# Altium schematic sheet PWR_Battery_Protection.SchDoc
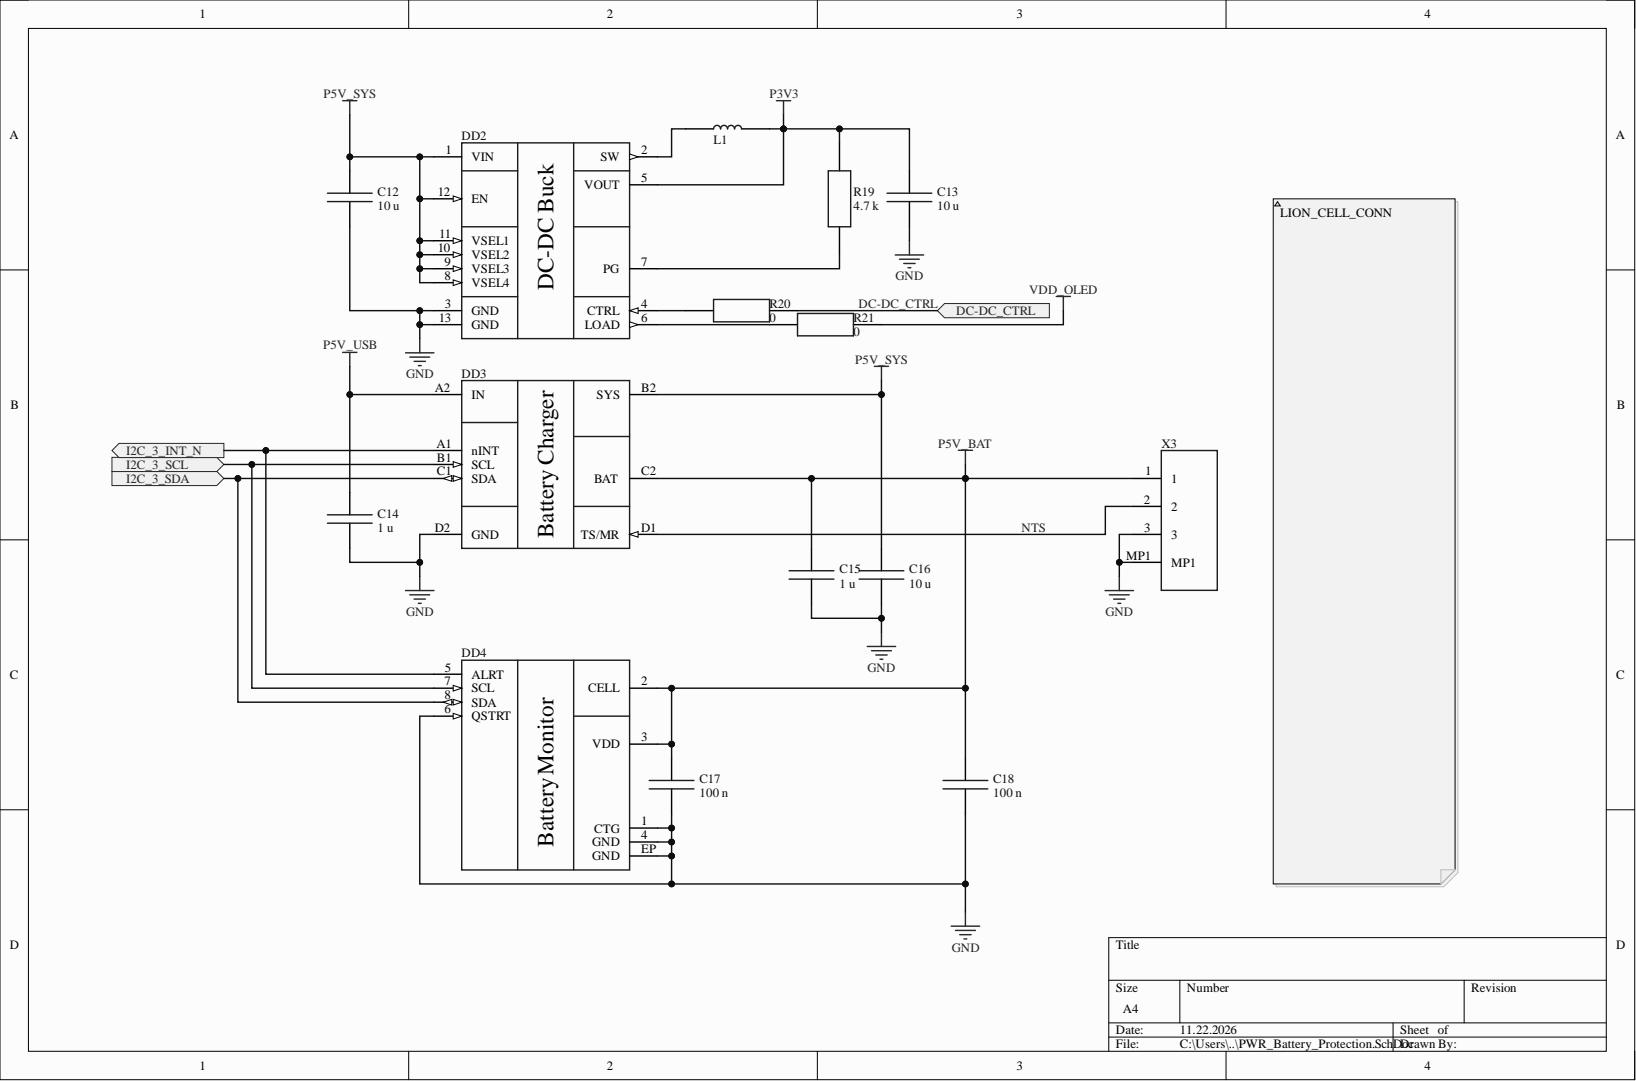
[diagram p0: PWR_Battery_Protection.SchDoc]

## Parts
C12  10 u  [C0603]
C13  10 u  [C0603]
C14  1 u  [C0603]
C15  1 u  [C0603]
C16  10 u  [C0603]
C17  100 n  [C0402]
C18  100 n  [C0402]
DD2  TPS62740DSSR  [WSON-12_3x2_P0.5_EP0.9x2]  (value not drawn)
DD3  BQ25180YBGR  [DSBGA_TI_BQ25180YBGR]  (value not drawn)
DD4  MAX17048G+T10  [TDFN-8_2x2_P0.5_EP0.8x1.38]  (value not drawn)
L1  2.2 uH  [IND3.3x3.3]  (value not drawn)
R19  4.7 k  [R0402]
R20  0  [R0402]
R21  0  [R0402]
X3  S3B-PH-SM4-TB(LF)(SN)  [JST_S3B-PH-SM4-TB_LF_SN]  (value not drawn)

## Ports
DC-DC_CTRL, I2C_3_INT_N, I2C_3_SCL, I2C_3_SDA

## Nets
GND: C12.2 C13.2 C14.2 C15.2 C16.2 C17.2 C18.2 DD2.3(GND) DD2.13(GND) DD3.D2(GND) DD4.1(CTG) DD4.4(GND) DD4.6(QSTRT) DD4.EP(GND) X3.3 X3.MP1
P3V3: C13.1 DD2.5(VOUT) L1.2 R19.2
P5V_BAT: C15.1 C17.1 C18.1 DD3.C2(BAT) DD4.2(CELL) DD4.3(VDD) X3.1
P5V_SYS: C12.1 C16.1 DD2.1(VIN) DD2.8(VSEL4) DD2.9(VSEL3) DD2.10(VSEL2) DD2.11(VSEL1) DD2.12(EN) DD3.B2(SYS)
P5V_USB: C14.1 DD3.A2(IN)
VDD_OLED: R21.2
DC-DC_CTRL: R20.2 ; port DC-DC_CTRL
I2C_3_INT_N: DD3.A1(nINT) DD4.5(ALRT) ; port I2C_3_INT_N
I2C_3_SCL: DD3.B1(SCL) DD4.7(SCL) ; port I2C_3_SCL
I2C_3_SDA: DD3.C1(SDA) DD4.8(SDA) ; port I2C_3_SDA
NTS: DD3.D1(TS/MR) X3.2
NetDD2_2: DD2.2(SW) L1.1
NetDD2_4: DD2.4(CTRL) R20.1
NetDD2_6: DD2.6(LOAD) R21.1
NetDD2_7: DD2.7(PG) R19.1

## Library data of the parts
C12 C13 C16 = C0603 X7R 10 uF 10% 16 V: MLCC 0603, X7R, 10 uF, 10%, 16 V; Capacitance: 10 uF; Database Table Name: C_Capacitor; ID: C_001120; Voltage Rating: 16 V; Component Kind: Standard; Dielectric: X7R; Library Name: Database_Libs1.DbLib; Mounting Technology: SMD; Package: C0603; Part Number: C0603 X7R 10 uF 10% 16 V; Temperature Range: -55...+125 C; Tolerance: 10%
C14 C15 = C0603 X7R 1 uF 10% 16 V: MLCC 0603, X7R, 1 uF, 10%, 16 V; Capacitance: 1 uF; Database Table Name: C_Capacitor; ID: C_000390; Voltage Rating: 16 V; Component Kind: Standard; Dielectric: X7R; Library Name: Database_Libs1.DbLib; Mounting Technology: SMD; Package: C0603; Part Number: C0603 X7R 1 uF 10% 16 V; Temperature Range: -55...+125 C; Tolerance: 10%
C17 C18 = C0402 X7R 100 nF 10% 16 V: MLCC 0402, X7R, 100 nF, 10%, 16 V; Capacitance: 100 nF; Database Table Name: C_Capacitor; ID: C_000136; Voltage Rating: 16 V; Component Kind: Standard; Dielectric: X7R; Library Name: Database_Libs1.DbLib; Mounting Technology: SMD; Package: C0402; Part Number: C0402 X7R 100 nF 10% 16 V; Temperature Range: -55...+125 C; Tolerance: 10%
DD2 = TPS62740DSSR: IC, BUCK REGULATOR, TPS62740DSSR, VIN 2.2-5.5V, VOUT 1.8-3.3V, 300mA, WSON12, 12PIN+EP, P0.5mm; Manufacturer: Texas Instruments; Database Table Name: IC_Power; ID: ICPWR_000002; Component Kind: Standard; ComponentLink1Description: Datasheet; ComponentLink1URL: https://www.ti.com/lit/ds/symlink/tps62740.pdf; Library Name: Database_Libs1.DbLib; Manufacturer Part Number: TPS62740DSSR; Mounting Technology: SMT; Package: WSON-12; Part Number: TPS62740DSSR
DD3 = BQ25180YBGR: IC, BATTERY CHARGER, BQ25180YBGR, 1CELL LI-ION, 1A, I2C, POWER PATH, DSBGA8, 8P, P0.4mm; Manufacturer: Texas Instruments; Database Table Name: IC_Power; ID: ICPWR_000001; Component Kind: Standard; ComponentLink1Description: Datasheet; ComponentLink1URL: https://www.ti.com/lit/ds/symlink/bq25180.pdf; Library Name: Database_Libs1.DbLib; Manufacturer Part Number: BQ25180YBGR; Mounting Technology: SMT; Package: DSBGA; Part Number: BQ25180YBGR
DD4 = MAX17048G+T10: IC, FUEL GAUGE, MAX17048G+T10, 1CELL LI-ION, I2C, TDFN8, 8P, P0.5mm; Manufacturer: Analog Devices; Database Table Name: IC_Power; ID: ICPWR_000003; Component Kind: Standard; ComponentLink1Description: Datasheet; ComponentLink1URL: https://www.analog.com/media/en/technical-documentation/data-sheets/MAX17048-MAX17049.pdf; Library Name: Database_Libs1.DbLib; Manufacturer Part Number: MAX17048G+T10; Mounting Technology: SMT; Package: TDFN-8; Part Number: MAX17048G+T10
L1 = LPS3314-222MRC: INDUCTOR, PWR,LPS3314-222MRC, 2.2 uH, 100 mOhm max, 3.3x3.3; Manufacturer: CoilCraft; Database Table Name: L_Inductor; ID: L_000004; Inductor Type: Multilayer power, shielded; Saturation Current: 1.3 A; Component Kind: Standard; ComponentLink1Description: Vendor datasheet; ComponentLink1URL: https://www.mouser.de/datasheet/3/995/1/lps3314.pdf; DCR: 100 mOhm max; Inductance: 2.2 uH; Library Name: Database_Libs1.DbLib; Manufacturer Part Number: LPS3314-222MRC; Mounting Technology: SMD; Package: 3.3x3.3; Part Number: LPS3314-222MRC
R19 = R0402 4.7 kOhm 1%: SMD resistor 0402, 4.7 kOhm, 1%; Database Table Name: R_Resistor; ID: R_000089; Component Kind: Standard; Library Name: Database_Libs1.DbLib; Mounting Technology: SMD; Package: R0402; Part Number: R0402 4.7 kOhm 1%; Resistance: 4.7 kOhm; Technology: Thick Film; Tolerance: 1%
R20 R21 = R0402 0 Ohm 1%: SMD resistor 0402, 0 Ohm, 1%; Database Table Name: R_Resistor; ID: R_000677; Component Kind: Standard; Library Name: Database_Libs1.DbLib; Mounting Technology: SMD; Package: R0402; Part Number: R0402 0 Ohm 1%; Resistance: 0; Technology: Thick Film; Tolerance: 1%
X3 = S3B-PH-SM4-TB(LF)(SN): CONN, WIRE-TO-BOARD, S3B-PH-SM4-TB(LF)(SN), JST PH, 3P, P2.0, MALE, RA, SMD; Connector Type: Wire-to-board header; Contact Count: 3; Current Rating: 2 A; Database Table Name: X_Connector; ID: X_000011; Pitch: 2.0 mm; Row Count: 1; Voltage Rating: 100 V; Component Kind: Standard; ComponentLink1Description: Manufacturer datasheet; ComponentLink1URL: https://www.jst-mfg.com/product/pdf/eng/ePH.pdf; Gender: Male; Library Name: Database_Libs1.DbLib; Manufacturer: JST; Manufacturer Part Number: S3B-PH-SM4-TB(LF)(SN); Mounting Technology: SMD; Package: JST PH, side entry; Part Number: S3B-PH-SM4-TB(LF)(SN)
